# Altium schematic sheet keyboard.SchDoc(keyboard)
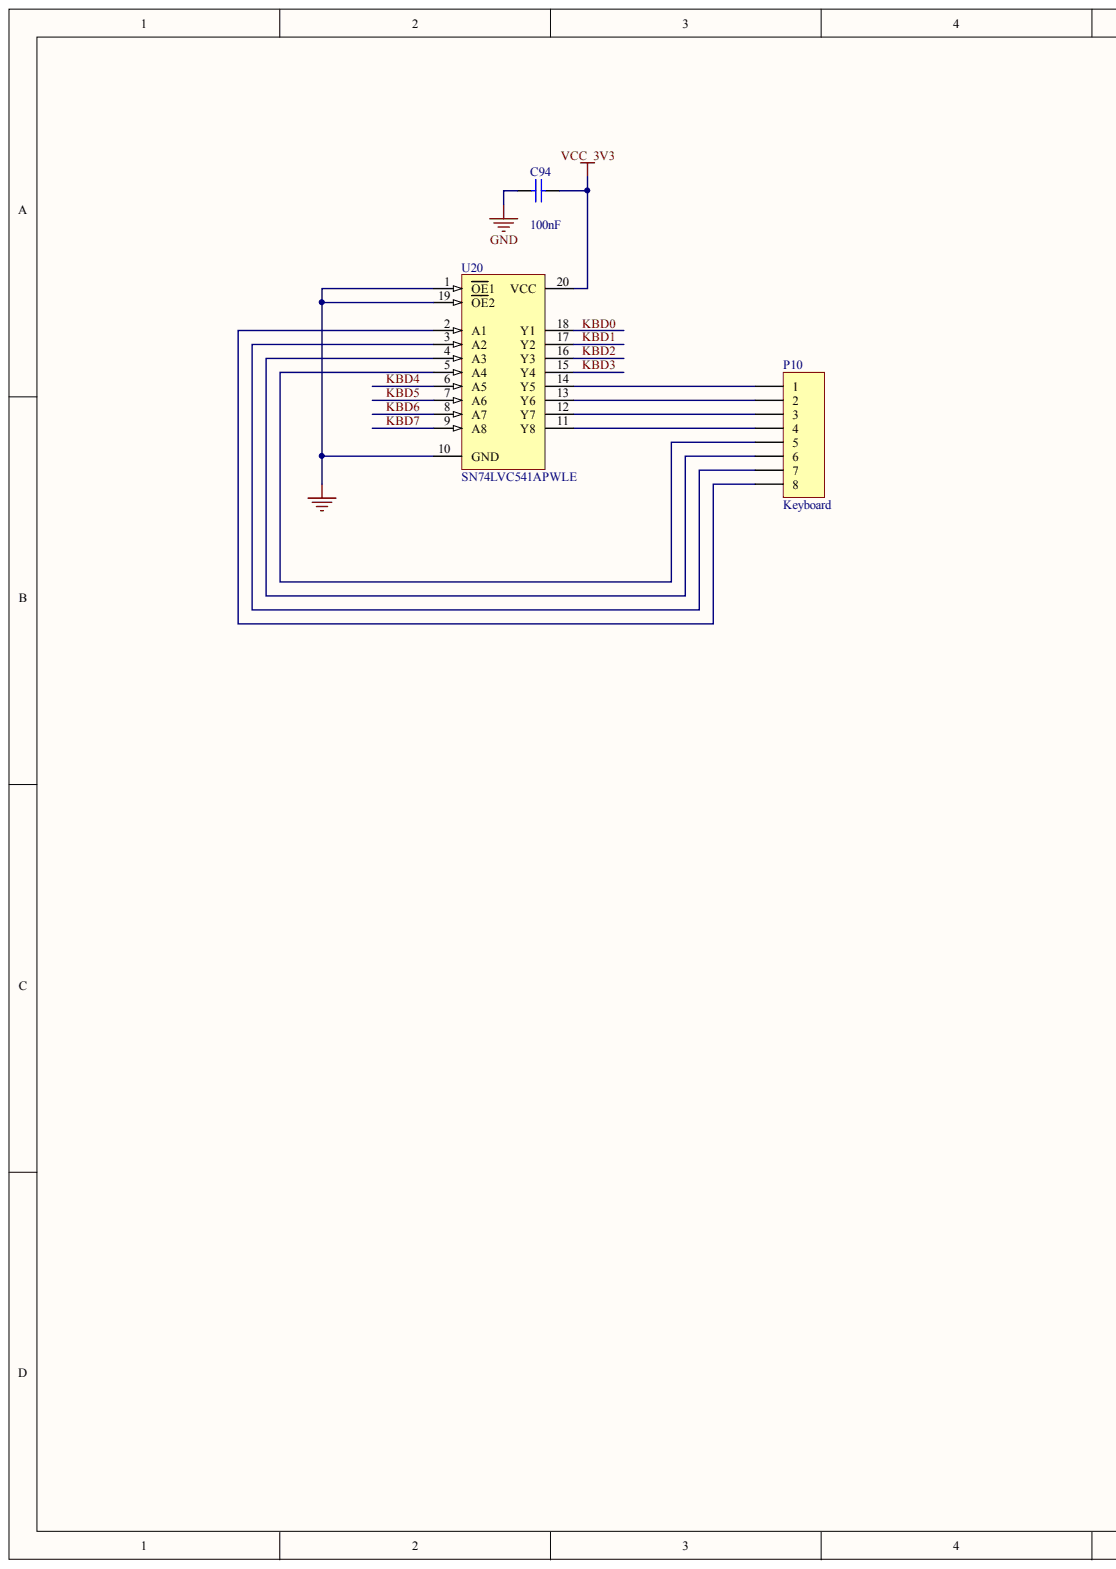
[diagram p0: keyboard.SchDoc, left]
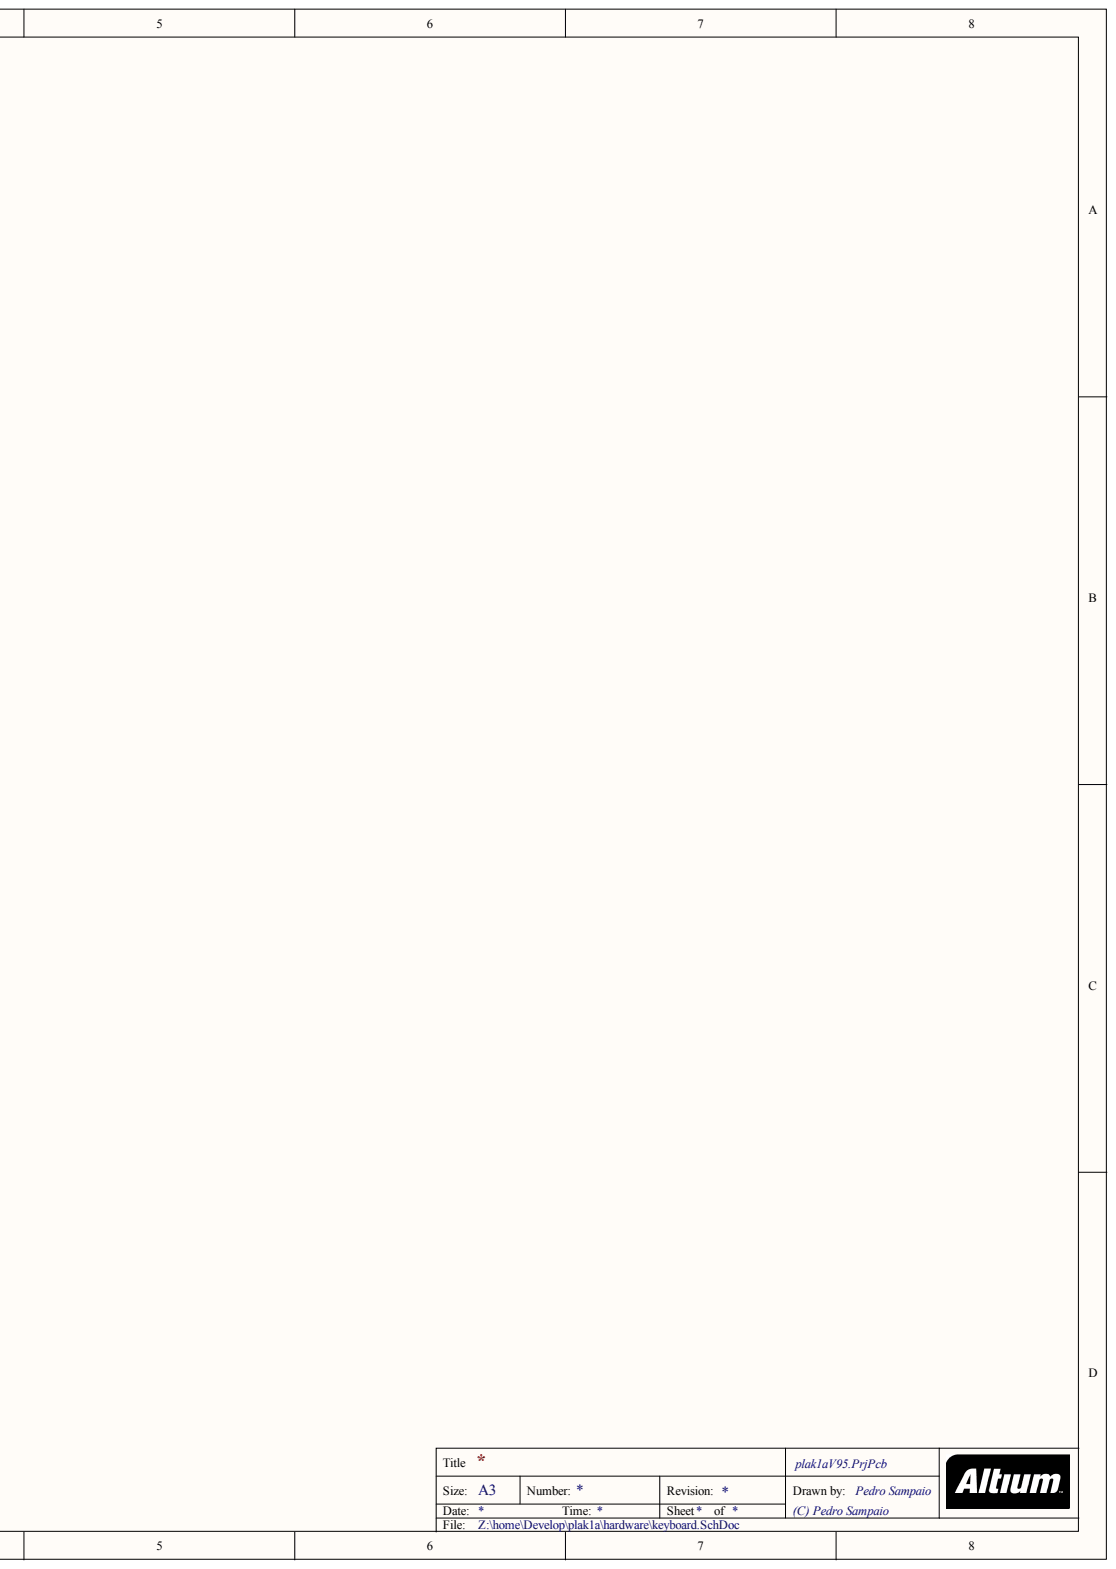
[diagram p1: keyboard.SchDoc, right]

## Parts
C94  value 100nF  [1608[0603]]  (hidden comment: 1301716)
P10  Keyboard  [MCAK1604NBWB]
U20  SN74LVC541APWLE  [PW020_N]

## Nets
GND: C94.1 U20.1(OE1) U20.10(GND) U20.19(OE2)
VCC_3V3: C94.2 U20.20(VCC)
KBD0: U20.18(Y1)
KBD1: U20.17(Y2)
KBD2: U20.16(Y3)
KBD3: U20.15(Y4)
KBD4: U20.6(A5)
KBD5: U20.7(A6)
KBD6: U20.8(A7)
KBD7: U20.9(A8)
NetP10_1: P10.1 U20.14(Y5)
NetP10_2: P10.2 U20.13(Y6)
NetP10_3: P10.3 U20.12(Y7)
NetP10_4: P10.4 U20.11(Y8)
NetP10_5: P10.5 U20.5(A4)
NetP10_6: P10.6 U20.4(A3)
NetP10_7: P10.7 U20.3(A2)
NetP10_8: P10.8 U20.2(A1)

## Library data of the parts
C94 = C0603: Ceramic Chip Capacitor - Standard; Note: Footprint corresponds to dimensions for bulk cassette packaging. This case size has tightened tolerances.; Code_IPC: 1608 [0603]; Code_JEITA: 0603; Component Kind: Standard; ComponentLink1Description: Manufacturer Link; ComponentLink1URL: http://www.kemet.com/; ComponentLink2Description: Datasheet; ComponentLink2URL: http://www.kemet.com/kemet/web/homepage/kechome.nsf/vapubfilesname/F3102TA.pdf/$file/F3102TA.pdf; DatasheetDocument: Mar-2001; Farnell: 1301716; LatestRevisionDate: 19-Mar-2004; LatestRevisionNote: Silkscreen size reduced.; Library Name: KEMET Chip Capacitor.IntLib; PackageDocument: Mar-2001; PackageReference: 0603; Published: 17-Jul-2002; Signal Integrity: C0603; Simulation: CAP; Publisher: Altium Limited
P10 = KEYPAD 4x4: Component Kind: Standard; Library Name: Componentes.IntLib
U20 = SN74LVC541APWLE: Octal Buffer/Driver with 3-State Outputs; ComponentAvailability: Obsolete; Component Kind: Standard; ComponentLink1Description: Manufacturer Link; ComponentLink1URL: http://www.ti.com/; ComponentLink2Description: Datasheet; ComponentLink2URL: http://www-s.ti.com/sc/ds/sn74lvc541a.pdf; DatasheetVersion: Jun-1998; LatestRevisionDate: 16-Oct-2006; LatestRevisionNote: IPC-7351 Footprint Added.; Library Name: TI Logic Buffer Line Driver.IntLib; PackageDescription: 20-Pin Thin Small Outline Package 0.65 mm Pitch; PackageReference: PW020; PackageVersion: Feb-1999; PCB3D: PW020; Published: 8-Jun-2000; Signal Integrity: SN74LVC541APWLE; Publisher: Altium Limited
